# Altium schematic sheet Out_current_sensor_UVW.SchDoc
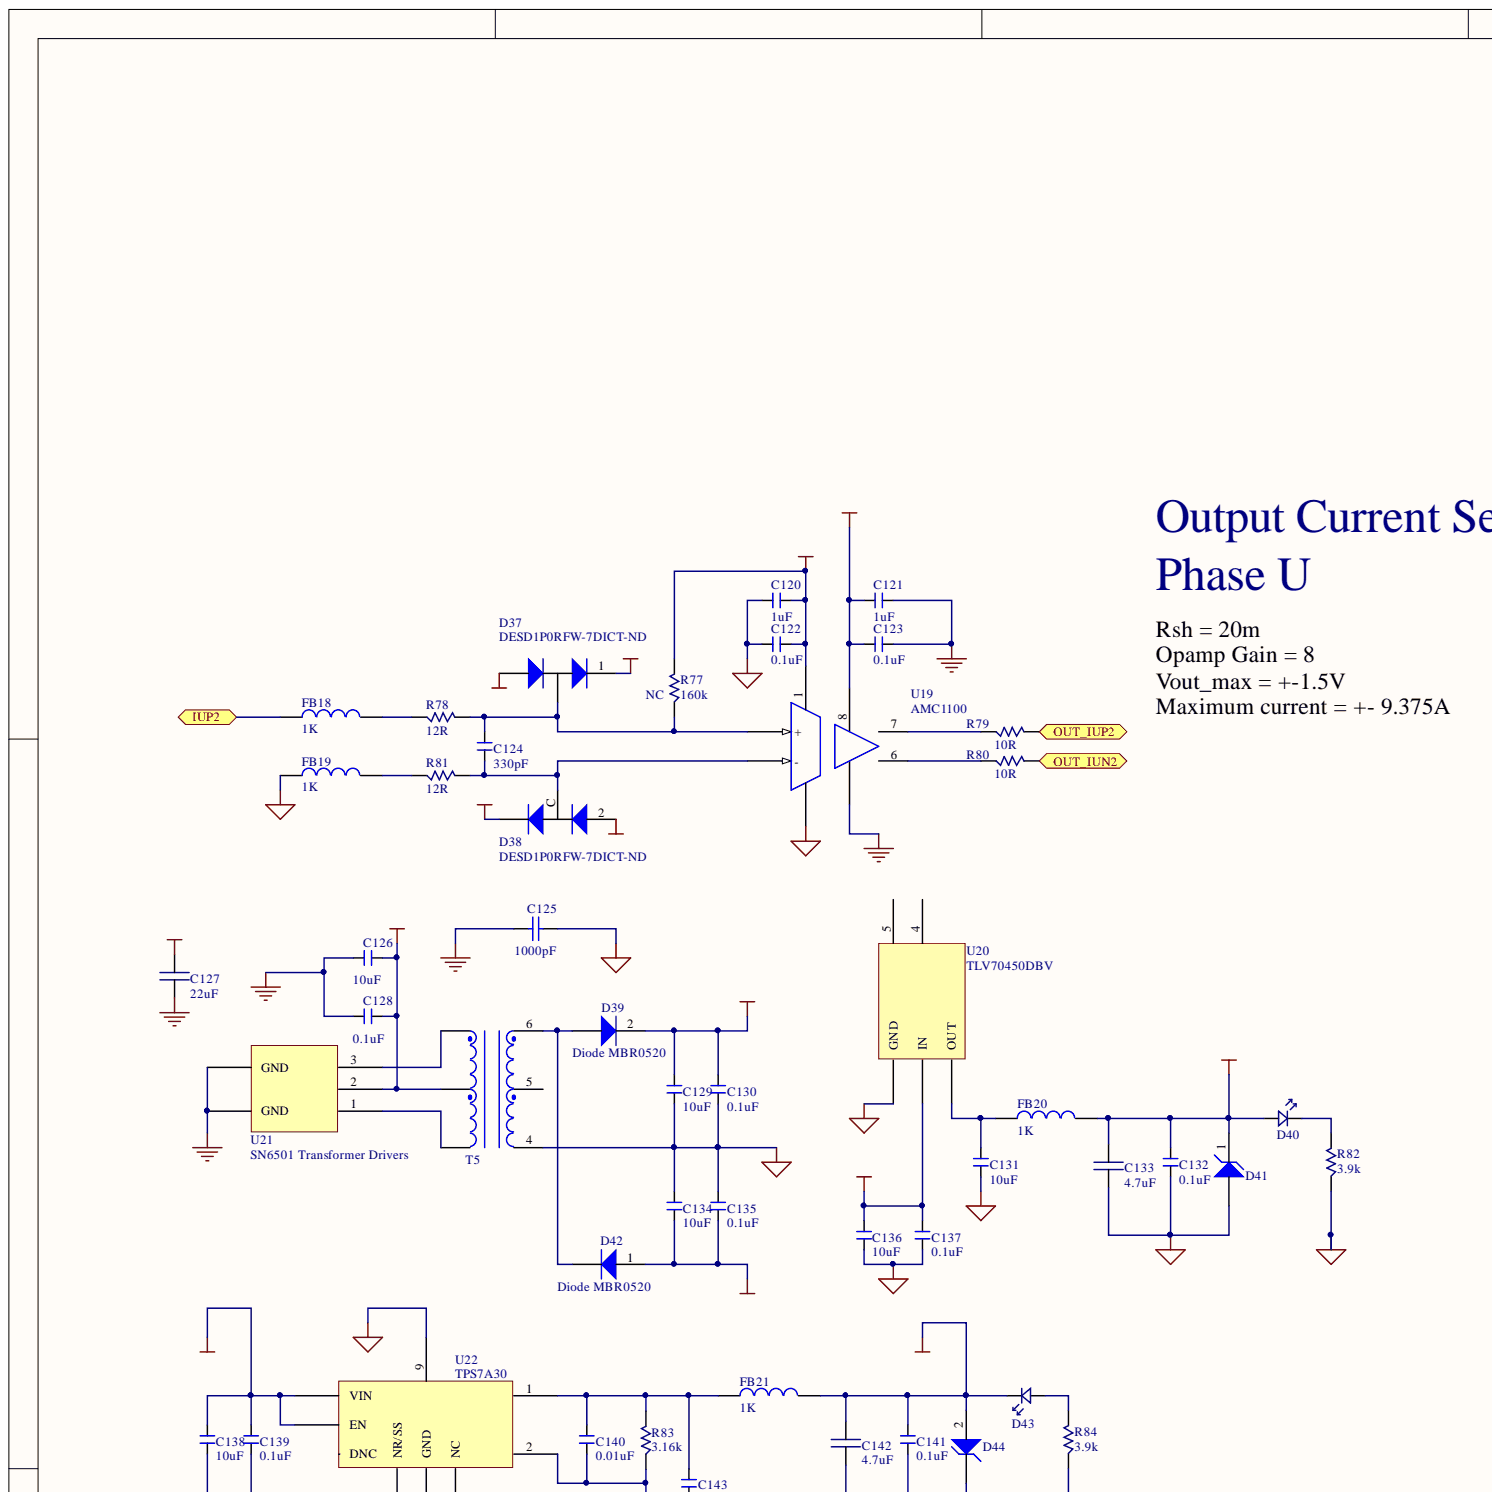
[diagram p0: Out_current_sensor_UVW.SchDoc, top left]
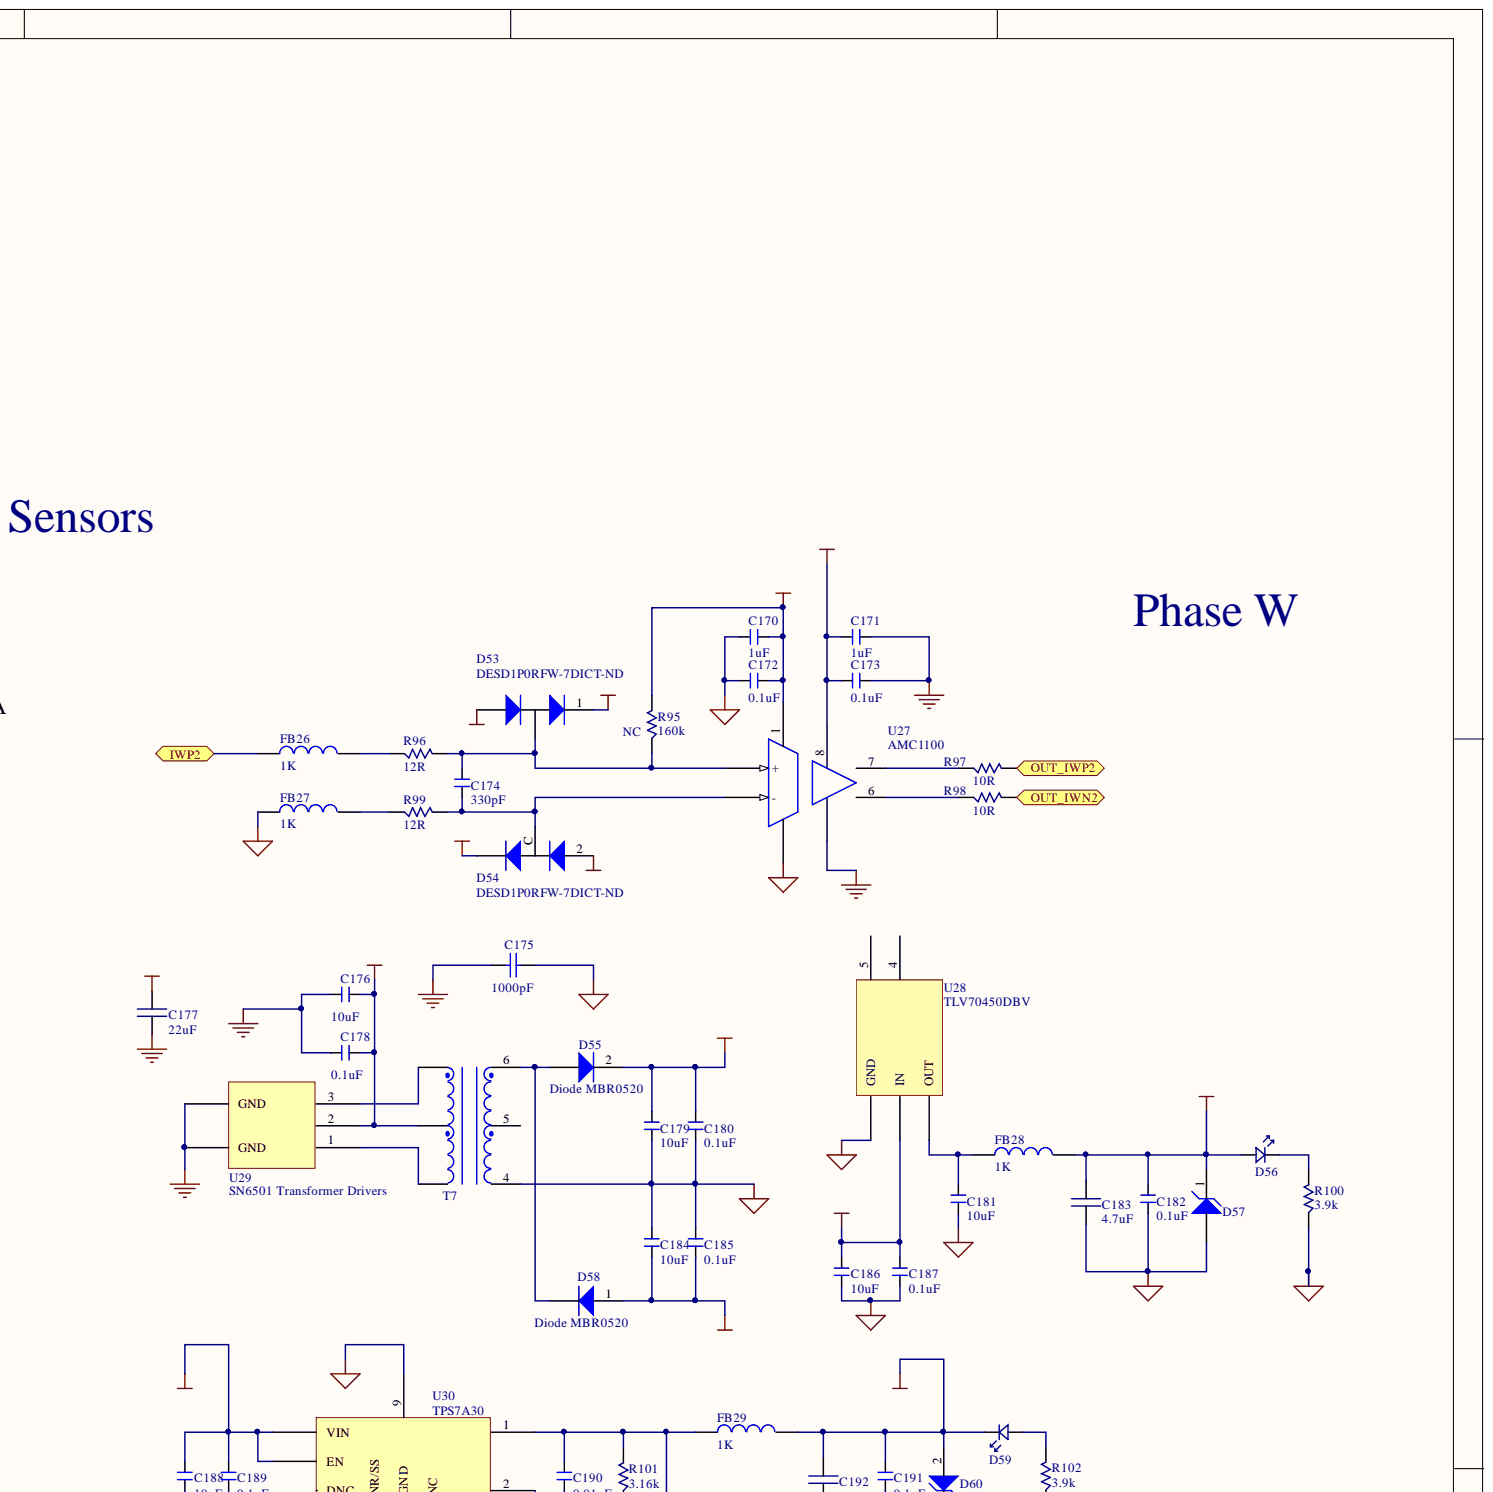
[diagram p1: Out_current_sensor_UVW.SchDoc, top right]
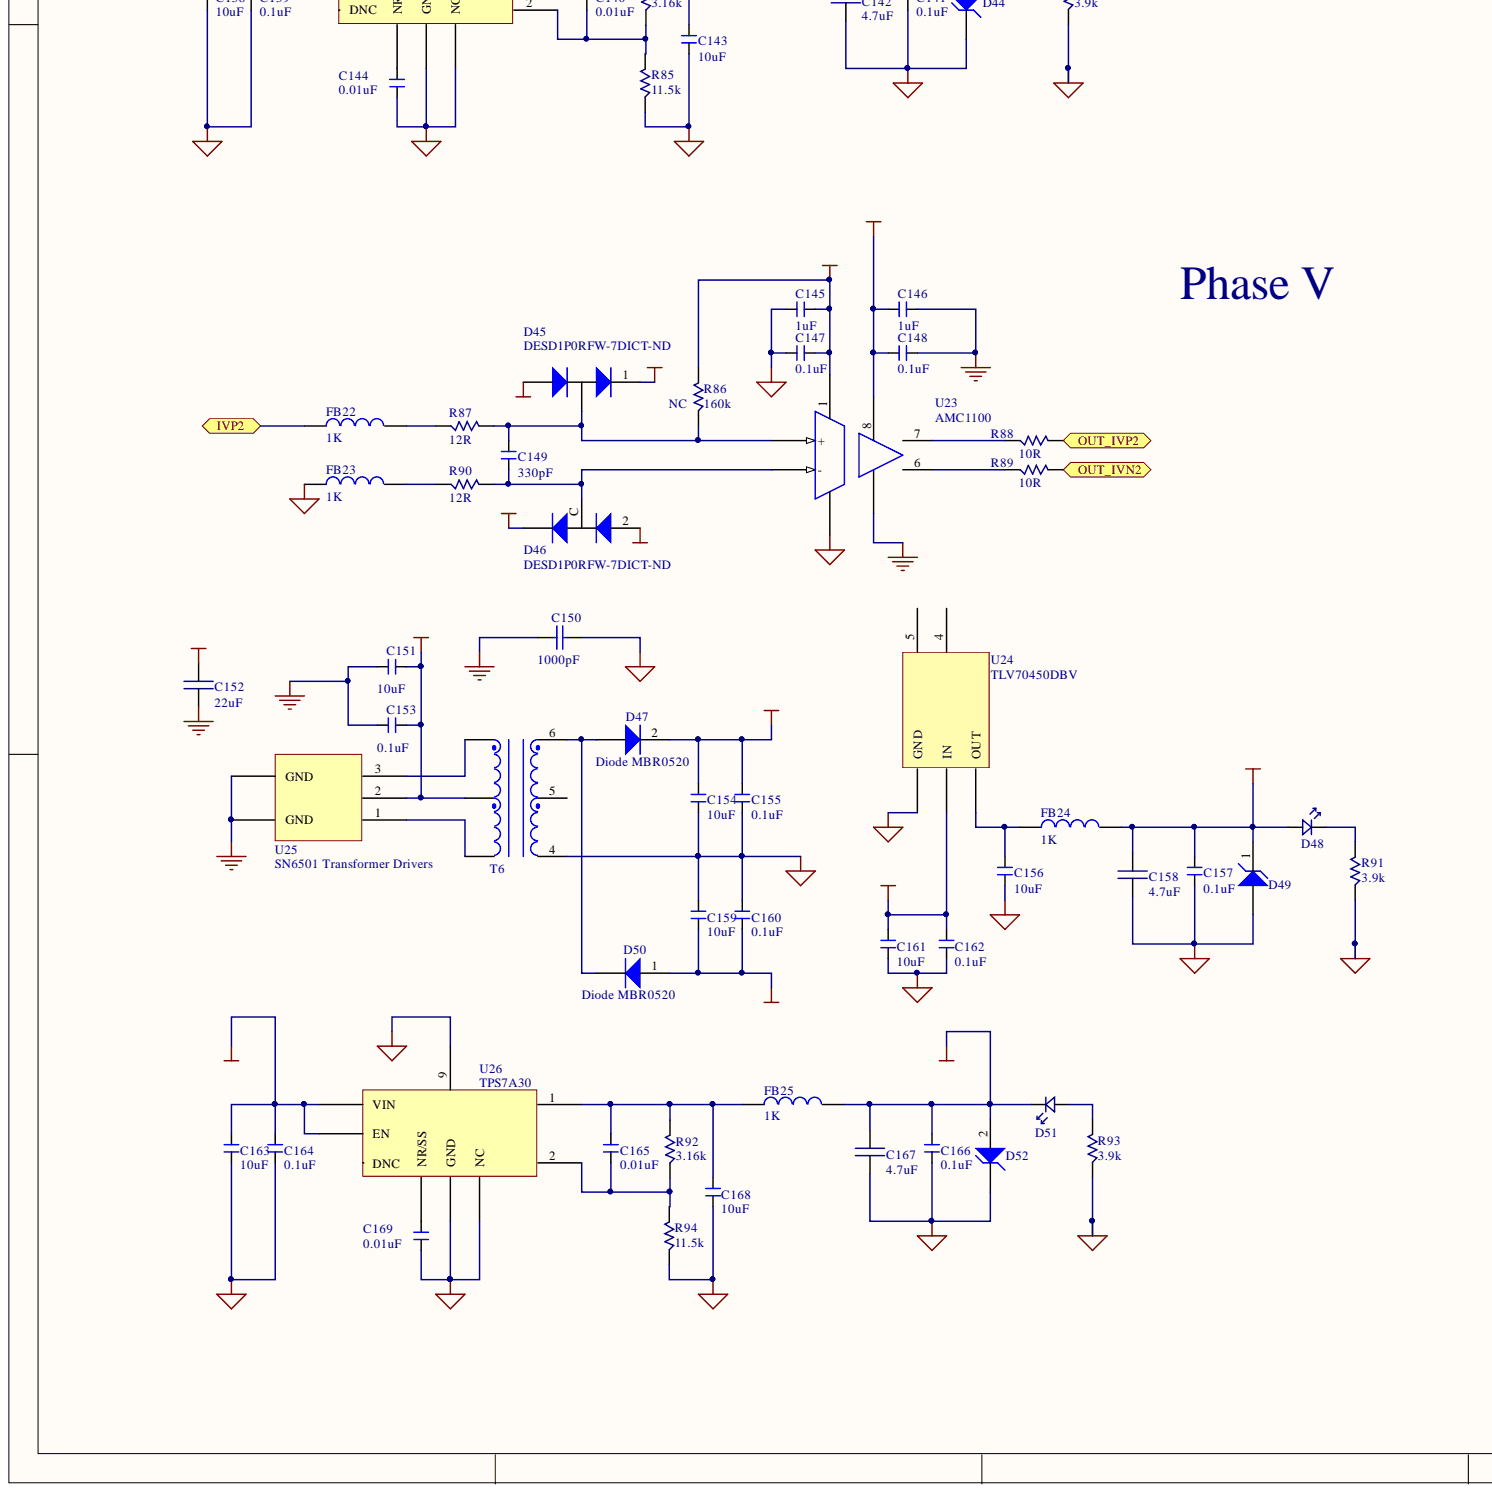
[diagram p2: Out_current_sensor_UVW.SchDoc, bottom left]
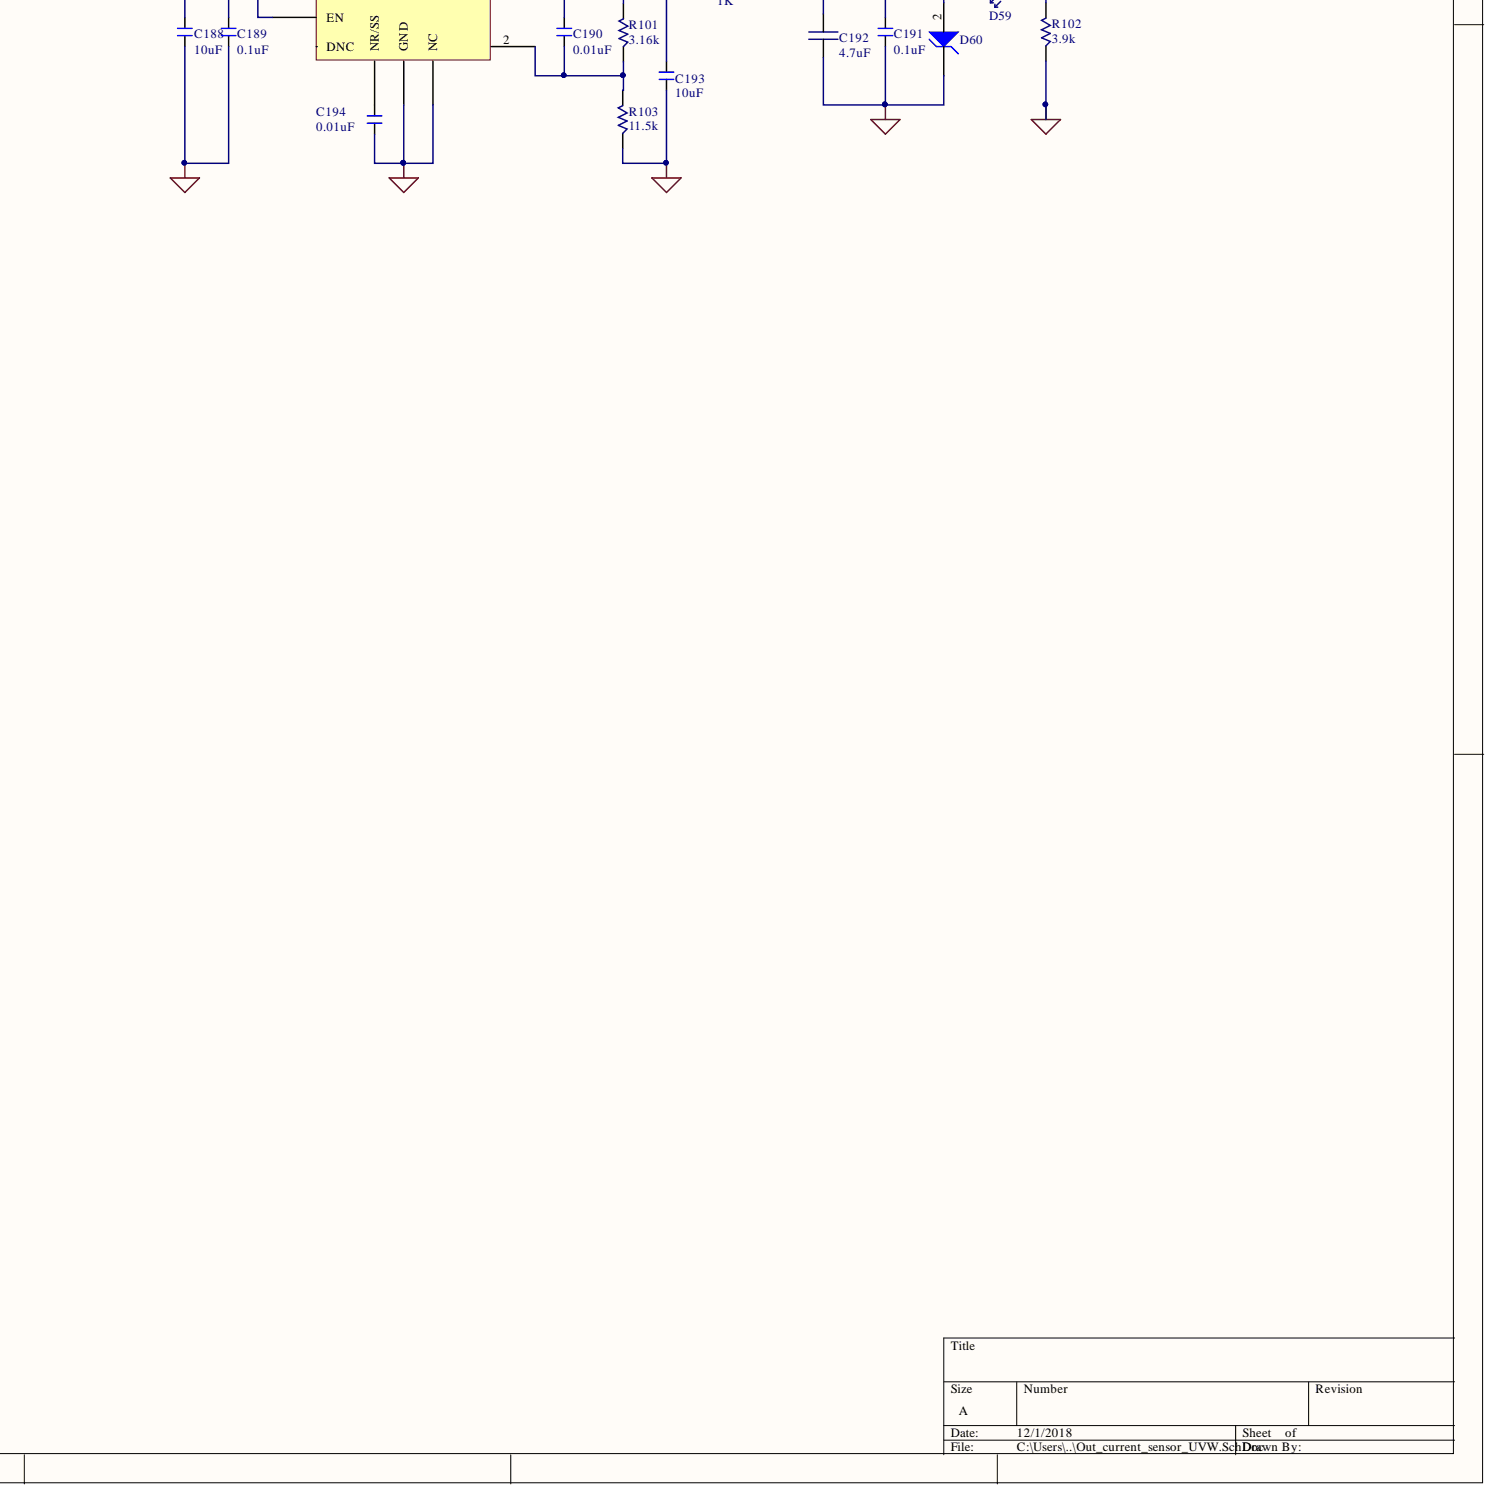
[diagram p3: Out_current_sensor_UVW.SchDoc, bottom right]

## Parts
C120  value 1uF  [0603 (1608 Metric)]  (hidden comment: Cap 0603_new)
C121  value 1uF  [0603 (1608 Metric)]  (hidden comment: Cap 0603_new)
C122  value 0.1uF  [0603 (1608 Metric)]  (hidden comment: Cap 0603_new)
C123  value 0.1uF  [0603 (1608 Metric)]  (hidden comment: Cap 0603_new)
C124  value 330pF  [0603 (1608 Metric)]  (hidden comment: Cap 0603_new)
C125  value 1000pF  [RAD-0.3]  (hidden comment: Cap TH)
C126  value 10uF  [0603 (1608 Metric)]  (hidden comment: Cap 0603_new)
C127  value 22uF  [0805 (2012 metric)]  (hidden comment: Cap 0805)
C128  value 0.1uF  [0603 (1608 Metric)]  (hidden comment: Cap 0603_new)
C129  value 10uF  [0603 (1608 Metric)]  (hidden comment: Cap 0603_new)
C130  value 0.1uF  [0603 (1608 Metric)]  (hidden comment: Cap 0603_new)
C131  value 10uF  [0603 (1608 Metric)]  (hidden comment: Cap 0603_new)
C132  value 0.1uF  [0603 (1608 Metric)]  (hidden comment: Cap 0603_new)
C133  value 4.7uF  [0805 (2012 metric)]  (hidden comment: Cap 0805)
C134  value 10uF  [0603 (1608 Metric)]  (hidden comment: Cap 0603_new)
C135  value 0.1uF  [0603 (1608 Metric)]  (hidden comment: Cap 0603_new)
C136  value 10uF  [0603 (1608 Metric)]  (hidden comment: Cap 0603_new)
C137  value 0.1uF  [0603 (1608 Metric)]  (hidden comment: Cap 0603_new)
C138  value 10uF  [0603 (1608 Metric)]  (hidden comment: Cap 0603_new)
C139  value 0.1uF  [0603 (1608 Metric)]  (hidden comment: Cap 0603_new)
C140  value 0.01uF  [0603 (1608 Metric)]  (hidden comment: Cap 0603_new)
C141  value 0.1uF  [0603 (1608 Metric)]  (hidden comment: Cap 0603_new)
C142  value 4.7uF  [0805 (2012 metric)]  (hidden comment: Cap 0805)
C143  value 10uF  [0603 (1608 Metric)]  (hidden comment: Cap 0603_new)
C144  value 0.01uF  [0603 (1608 Metric)]  (hidden comment: Cap 0603_new)
C145  value 1uF  [0603 (1608 Metric)]  (hidden comment: Cap 0603_new)
C146  value 1uF  [0603 (1608 Metric)]  (hidden comment: Cap 0603_new)
C147  value 0.1uF  [0603 (1608 Metric)]  (hidden comment: Cap 0603_new)
C148  value 0.1uF  [0603 (1608 Metric)]  (hidden comment: Cap 0603_new)
C149  value 330pF  [0603 (1608 Metric)]  (hidden comment: Cap 0603_new)
C150  value 1000pF  [RAD-0.3]  (hidden comment: Cap TH)
C151  value 10uF  [0603 (1608 Metric)]  (hidden comment: Cap 0603_new)
C152  value 22uF  [0805 (2012 metric)]  (hidden comment: Cap 0805)
C153  value 0.1uF  [0603 (1608 Metric)]  (hidden comment: Cap 0603_new)
C154  value 10uF  [0603 (1608 Metric)]  (hidden comment: Cap 0603_new)
C155  value 0.1uF  [0603 (1608 Metric)]  (hidden comment: Cap 0603_new)
C156  value 10uF  [0603 (1608 Metric)]  (hidden comment: Cap 0603_new)
C157  value 0.1uF  [0603 (1608 Metric)]  (hidden comment: Cap 0603_new)
C158  value 4.7uF  [0805 (2012 metric)]  (hidden comment: Cap 0805)
C159  value 10uF  [0603 (1608 Metric)]  (hidden comment: Cap 0603_new)
C160  value 0.1uF  [0603 (1608 Metric)]  (hidden comment: Cap 0603_new)
C161  value 10uF  [0603 (1608 Metric)]  (hidden comment: Cap 0603_new)
C162  value 0.1uF  [0603 (1608 Metric)]  (hidden comment: Cap 0603_new)
C163  value 10uF  [0603 (1608 Metric)]  (hidden comment: Cap 0603_new)
C164  value 0.1uF  [0603 (1608 Metric)]  (hidden comment: Cap 0603_new)
C165  value 0.01uF  [0603 (1608 Metric)]  (hidden comment: Cap 0603_new)
C166  value 0.1uF  [0603 (1608 Metric)]  (hidden comment: Cap 0603_new)
C167  value 4.7uF  [0805 (2012 metric)]  (hidden comment: Cap 0805)
C168  value 10uF  [0603 (1608 Metric)]  (hidden comment: Cap 0603_new)
C169  value 0.01uF  [0603 (1608 Metric)]  (hidden comment: Cap 0603_new)
C170  value 1uF  [0603 (1608 Metric)]  (hidden comment: Cap 0603_new)
C171  value 1uF  [0603 (1608 Metric)]  (hidden comment: Cap 0603_new)
C172  value 0.1uF  [0603 (1608 Metric)]  (hidden comment: Cap 0603_new)
C173  value 0.1uF  [0603 (1608 Metric)]  (hidden comment: Cap 0603_new)
C174  value 330pF  [0603 (1608 Metric)]  (hidden comment: Cap 0603_new)
C175  value 1000pF  [RAD-0.3]  (hidden comment: Cap TH)
C176  value 10uF  [0603 (1608 Metric)]  (hidden comment: Cap 0603_new)
C177  value 22uF  [0805 (2012 metric)]  (hidden comment: Cap 0805)
C178  value 0.1uF  [0603 (1608 Metric)]  (hidden comment: Cap 0603_new)
C179  value 10uF  [0603 (1608 Metric)]  (hidden comment: Cap 0603_new)
C180  value 0.1uF  [0603 (1608 Metric)]  (hidden comment: Cap 0603_new)
C181  value 10uF  [0603 (1608 Metric)]  (hidden comment: Cap 0603_new)
C182  value 0.1uF  [0603 (1608 Metric)]  (hidden comment: Cap 0603_new)
C183  value 4.7uF  [0805 (2012 metric)]  (hidden comment: Cap 0805)
C184  value 10uF  [0603 (1608 Metric)]  (hidden comment: Cap 0603_new)
C185  value 0.1uF  [0603 (1608 Metric)]  (hidden comment: Cap 0603_new)
C186  value 10uF  [0603 (1608 Metric)]  (hidden comment: Cap 0603_new)
C187  value 0.1uF  [0603 (1608 Metric)]  (hidden comment: Cap 0603_new)
C188  value 10uF  [0603 (1608 Metric)]  (hidden comment: Cap 0603_new)
C189  value 0.1uF  [0603 (1608 Metric)]  (hidden comment: Cap 0603_new)
C190  value 0.01uF  [0603 (1608 Metric)]  (hidden comment: Cap 0603_new)
C191  value 0.1uF  [0603 (1608 Metric)]  (hidden comment: Cap 0603_new)
C192  value 4.7uF  [0805 (2012 metric)]  (hidden comment: Cap 0805)
C193  value 10uF  [0603 (1608 Metric)]  (hidden comment: Cap 0603_new)
C194  value 0.01uF  [0603 (1608 Metric)]  (hidden comment: Cap 0603_new)
D37  Part: DESD1P0RFW-7DICT-ND  [SOT323_ESD]  (hidden comment: TVS - Diodes)
D38  Part: DESD1P0RFW-7DICT-ND  [SOT323_ESD]  (hidden comment: TVS - Diodes)
D39  Diode MBR0520  [SOD-123]
D40  LED  [0805 (2012 Metric) Diode]  (value not drawn)
D41  (no value)  [POWERDI]
D42  Diode MBR0520  [SOD-123]
D43  LED  [0805 (2012 Metric) Diode]  (value not drawn)
D44  (no value)  [POWERDI]
D45  Part: DESD1P0RFW-7DICT-ND  [SOT323_ESD]  (hidden comment: TVS - Diodes)
D46  Part: DESD1P0RFW-7DICT-ND  [SOT323_ESD]  (hidden comment: TVS - Diodes)
D47  Diode MBR0520  [SOD-123]
D48  LED  [0805 (2012 Metric) Diode]  (value not drawn)
D49  (no value)  [POWERDI]
D50  Diode MBR0520  [SOD-123]
D51  LED  [0805 (2012 Metric) Diode]  (value not drawn)
D52  (no value)  [POWERDI]
D53  Part: DESD1P0RFW-7DICT-ND  [SOT323_ESD]  (hidden comment: TVS - Diodes)
D54  Part: DESD1P0RFW-7DICT-ND  [SOT323_ESD]  (hidden comment: TVS - Diodes)
D55  Diode MBR0520  [SOD-123]
D56  LED  [0805 (2012 Metric) Diode]  (value not drawn)
D57  (no value)  [POWERDI]
D58  Diode MBR0520  [SOD-123]
D59  LED  [0805 (2012 Metric) Diode]  (value not drawn)
D60  (no value)  [POWERDI]
FB18  value 1K  [0603 (1608 Metric)]  (hidden comment: Ferrite bead)
FB19  value 1K  [0603 (1608 Metric)]  (hidden comment: Ferrite bead)
FB20  value 1K  [0603 (1608 Metric)]  (hidden comment: Ferrite bead)
FB21  value 1K  [0603 (1608 Metric)]  (hidden comment: Ferrite bead)
FB22  value 1K  [0603 (1608 Metric)]  (hidden comment: Ferrite bead)
FB23  value 1K  [0603 (1608 Metric)]  (hidden comment: Ferrite bead)
FB24  value 1K  [0603 (1608 Metric)]  (hidden comment: Ferrite bead)
FB25  value 1K  [0603 (1608 Metric)]  (hidden comment: Ferrite bead)
FB26  value 1K  [0603 (1608 Metric)]  (hidden comment: Ferrite bead)
FB27  value 1K  [0603 (1608 Metric)]  (hidden comment: Ferrite bead)
FB28  value 1K  [0603 (1608 Metric)]  (hidden comment: Ferrite bead)
FB29  value 1K  [0603 (1608 Metric)]  (hidden comment: Ferrite bead)
R77  NC  value 160k  [0603 (1608 Metric)]
R78  value 12R  [1206]  (hidden comment: Resistor 1206)
R79  value 10R  [0603 (1608 Metric)]  (hidden comment: Resistor 0603)
R80  value 10R  [0603 (1608 Metric)]  (hidden comment: Resistor 0603)
R81  value 12R  [1206]  (hidden comment: Resistor 1206)
R82  value 3.9k  [0603 (1608 Metric)]  (hidden comment: Resistor 0603)
R83  value 3.16k  [0603 (1608 Metric)]  (hidden comment: Resistor 0603)
R84  value 3.9k  [0603 (1608 Metric)]  (hidden comment: Resistor 0603)
R85  value 11.5k  [0603 (1608 Metric)]  (hidden comment: Resistor 0603)
R86  NC  value 160k  [0603 (1608 Metric)]
R87  value 12R  [1206]  (hidden comment: Resistor 1206)
R88  value 10R  [0603 (1608 Metric)]  (hidden comment: Resistor 0603)
R89  value 10R  [0603 (1608 Metric)]  (hidden comment: Resistor 0603)
R90  value 12R  [1206]  (hidden comment: Resistor 1206)
R91  value 3.9k  [0603 (1608 Metric)]  (hidden comment: Resistor 0603)
R92  value 3.16k  [0603 (1608 Metric)]  (hidden comment: Resistor 0603)
R93  value 3.9k  [0603 (1608 Metric)]  (hidden comment: Resistor 0603)
R94  value 11.5k  [0603 (1608 Metric)]  (hidden comment: Resistor 0603)
R95  NC  value 160k  [0603 (1608 Metric)]
R96  value 12R  [1206]  (hidden comment: Resistor 1206)
R97  value 10R  [0603 (1608 Metric)]  (hidden comment: Resistor 0603)
R98  value 10R  [0603 (1608 Metric)]  (hidden comment: Resistor 0603)
R99  value 12R  [1206]  (hidden comment: Resistor 1206)
R100  value 3.9k  [0603 (1608 Metric)]  (hidden comment: Resistor 0603)
R101  value 3.16k  [0603 (1608 Metric)]  (hidden comment: Resistor 0603)
R102  value 3.9k  [0603 (1608 Metric)]  (hidden comment: Resistor 0603)
R103  value 11.5k  [0603 (1608 Metric)]  (hidden comment: Resistor 0603)
T5  750313769  [Xmr SN6501]  (value not drawn)
T6  750313769  [Xmr SN6501]  (value not drawn)
T7  750313769  [Xmr SN6501]  (value not drawn)
U19  AMC1100  [SOIC8]
U20  TLV70450DBV  [SOT23-5]
U21  SN6501 Transformer Drivers  [SOT23-5]
U22  TPS7A30  [8-TSSOP]
U23  AMC1100  [SOIC8]
U24  TLV70450DBV  [SOT23-5]
U25  SN6501 Transformer Drivers  [SOT23-5]
U26  TPS7A30  [8-TSSOP]
U27  AMC1100  [SOIC8]
U28  TLV70450DBV  [SOT23-5]
U29  SN6501 Transformer Drivers  [SOT23-5]
U30  TPS7A30  [8-TSSOP]

## Ports
IUP2, IVP2, IWP2, OUT_IUN2, OUT_IUP2, OUT_IVN2, OUT_IVP2, OUT_IWN2, OUT_IWP2

## Nets
+5V_LV: C121.1 C123.1 C126.2 C127.2 C128.2 C146.1 C148.1 C151.2 C152.2 C153.2 C171.1 C173.1 C176.2 C177.2 C178.2 T5.2 T6.2 T7.2 U19.8 U21.2 U23.8 U25.2 U27.8 U29.2
+5V_USH2: C120.2 C122.2 C132.2 C133.2 D37.1 D38.1 D40.A D41.1 FB20.2 R77.2 U19.1
+5V_VSH2: C145.2 C147.2 C157.2 C158.2 D45.1 D46.1 D48.A D49.1 FB24.2 R86.2 U23.1
+5V_WSH2: C170.2 C172.2 C182.2 C183.2 D53.1 D54.1 D56.A D57.1 FB28.2 R95.2 U27.1
+6V_USH2: C129.2 C130.2 C136.2 C137.2 D39.2 U20.2(IN)
+6V_VSH2: C154.2 C155.2 C161.2 C162.2 D47.2 U24.2(IN)
+6V_WSH2: C179.2 C180.2 C186.2 C187.2 D55.2 U28.2(IN)
-5V_USH2: C141.2 C142.2 D37.2 D38.2 D43.K D44.2 FB21.2
-5V_VSH2: C166.2 C167.2 D45.2 D46.2 D51.K D52.2 FB25.2
-5V_WSH2: C191.2 C192.2 D53.2 D54.2 D59.K D60.2 FB29.2
-6V_USH2: C134.1 C135.1 C138.2 C139.2 D42.1 U22.5(EN) U22.8(VIN)
-6V_VSH2: C159.1 C160.1 C163.2 C164.2 D50.1 U26.5(EN) U26.8(VIN)
-6V_WSH2: C184.1 C185.1 C188.2 C189.2 D58.1 U30.5(EN) U30.8(VIN)
GND_LV: C121.2 C123.2 C125.1 C126.1 C127.1 C128.1 C146.2 C148.2 C150.1 C151.1 C152.1 C153.1 C171.2 C173.2 C175.1 C176.1 C177.1 C178.1 U19.5 U21.4(GND) U21.5(GND) U23.5 U25.4(GND) U25.5(GND) U27.5 U29.4(GND) U29.5(GND)
GND_USH2: C120.1 C122.1 C125.2 C129.1 C130.1 C131.1 C132.1 C133.1 C134.2 C135.2 C136.1 C137.1 C138.1 C139.1 C141.1 C142.1 C143.1 C144.1 D41.2 D44.1 FB19.1 R82.1 R84.1 R85.1 T5.4 U19.4 U20.1(GND) U22.3(NC) U22.4(GND) U22.9
GND_VSH2: C145.1 C147.1 C150.2 C154.1 C155.1 C156.1 C157.1 C158.1 C159.2 C160.2 C161.1 C162.1 C163.1 C164.1 C166.1 C167.1 C168.1 C169.1 D49.2 D52.1 FB23.1 R91.1 R93.1 R94.1 T6.4 U23.4 U24.1(GND) U26.3(NC) U26.4(GND) U26.9
GND_WSH2: C170.1 C172.1 C175.2 C179.1 C180.1 C181.1 C182.1 C183.1 C184.2 C185.2 C186.1 C187.1 C188.1 C189.1 C191.1 C192.1 C193.1 C194.1 D57.2 D60.1 FB27.1 R100.1 R102.1 R103.1 T7.4 U27.4 U28.1(GND) U30.3(NC) U30.4(GND) U30.9
IUP2: FB18.1 ; port IUP2
IVP2: FB22.1 ; port IVP2
IWP2: FB26.1 ; port IWP2
OUT_IUN2: R80.2 ; port OUT_IUN2
OUT_IUP2: R79.2 ; port OUT_IUP2
OUT_IVN2: R89.2 ; port OUT_IVN2
OUT_IVP2: R88.2 ; port OUT_IVP2
OUT_IWN2: R98.2 ; port OUT_IWN2
OUT_IWP2: R97.2 ; port OUT_IWP2
NetC124_1: C124.1 D37.C R77.1 R78.2 U19.2(+)
NetC124_2: C124.2 D38.C R81.2 U19.3(-)
NetC131_2: C131.2 FB20.1 U20.3(OUT)
NetC140_1: C140.1 C143.2 FB21.1 R83.2 U22.1
NetC140_2: C140.2 R83.1 R85.2 U22.2
NetC144_2: C144.2 U22.6(NR/SS)
NetC149_1: C149.1 D45.C R86.1 R87.2 U23.2(+)
NetC149_2: C149.2 D46.C R90.2 U23.3(-)
NetC156_2: C156.2 FB24.1 U24.3(OUT)
NetC165_1: C165.1 C168.2 FB25.1 R92.2 U26.1
NetC165_2: C165.2 R92.1 R94.2 U26.2
NetC169_2: C169.2 U26.6(NR/SS)
NetC174_1: C174.1 D53.C R95.1 R96.2 U27.2(+)
NetC174_2: C174.2 D54.C R99.2 U27.3(-)
NetC181_2: C181.2 FB28.1 U28.3(OUT)
NetC190_1: C190.1 C193.2 FB29.1 R101.2 U30.1
NetC190_2: C190.2 R101.1 R103.2 U30.2
NetC194_2: C194.2 U30.6(NR/SS)
NetD39_1: D39.1 D42.2 T5.6
NetD40_K: D40.K R82.2
NetD43_A: D43.A R84.2
NetD47_1: D47.1 D50.2 T6.6
NetD48_K: D48.K R91.2
NetD51_A: D51.A R93.2
NetD55_1: D55.1 D58.2 T7.6
NetD56_K: D56.K R100.2
NetD59_A: D59.A R102.2
NetFB18_2: FB18.2 R78.1
NetFB19_2: FB19.2 R81.1
NetFB22_2: FB22.2 R87.1
NetFB23_2: FB23.2 R90.1
NetFB26_2: FB26.2 R96.1
NetFB27_2: FB27.2 R99.1
NetR79_1: R79.1 U19.7
NetR80_1: R80.1 U19.6
NetR88_1: R88.1 U23.7
NetR89_1: R89.1 U23.6
NetR97_1: R97.1 U27.7
NetR98_1: R98.1 U27.6
NetT5_1: T5.1 U21.3
NetT5_3: T5.3 U21.1
NetT6_1: T6.1 U25.3
NetT6_3: T6.3 U25.1
NetT7_1: T7.1 U29.3
NetT7_3: T7.3 U29.1

Not connected: T5 5; T6 5; T7 5; U20 4 5; U22 7; U24 4 5; U26 7; U28 4 5; U30 7

## Library data of the parts
C120 C121 C145 C146 C170 C171 = Cap 0603_new: CAP CER 1UF 50V X5R 0603; part: 1276-1860-1-ND; Component Kind: Standard; Library Name: Library_Microgrid.SchLib
C122 C123 C128 C130 C132 C135 C137 C139 C141 C147 C148 C153 C155 C157 C160 C162 C164 C166 C172 C173 C178 C180 C182 C185 C187 C189 C191 = Cap 0603_new: CAP CER 0.1UF 50V Y5V 0603; part: 311-1343-6-ND; Component Kind: Standard; Library Name: Library_Microgrid.SchLib
  C128 C178: Value: 0.1uF
C124 C149 C174 = Cap 0603_new: CAP CER 330PF 50V C0G 0603; part: 445-7033-2-ND; Component Kind: Standard; Library Name: Library_Microgrid.SchLib
C125 C150 C175 = Cap: CAP CER 1000PF 1KV Y5P RADIAL; Part: 1251PH-ND; Component Kind: Standard; LatestRevisionDate: 17-Jul-2002; LatestRevisionNote: Re-released for DXP Platform.; Library Name: Miscellaneous Devices.IntLib; PackageDescription: Radial Cap, Thru-Hole; 2 Leads; 0.3 in Pin Spacing; PackageReference: RAD-0.3; Published: 8-Jun-2000; Signal Integrity: Cap; Simulation: CAP; Publisher: Altium Limited
C126 C129 C131 C134 C136 C138 C143 C151 C154 C156 C159 C161 C163 C168 C176 C179 C181 C184 C186 C188 C193 = Cap 0603_new: CAP CER 10UF 35V X5R 0805; part: 490-10515-1-ND; Component Kind: Standard; Library Name: Library_Microgrid.SchLib
C127 C152 C177 = Cap 0805: CAP CER 22UF 35V X5R 0805; Part: 445-14428-1-ND; Component Kind: Standard; Library Name: Library_Microgrid.SchLib
C133 C142 C158 C167 C183 C192 = Cap 0805: CAP CER 4.7UF 50V X5R 0805; Part: 445-5980-1-ND; Component Kind: Standard; Library Name: Library_Microgrid.SchLib
C140 C144 C165 C169 C190 C194 = Cap 0603_new: CAP CER 10000PF 100V X7R 0603; part: 478-7418-1-ND; Component Kind: Standard; Library Name: Library_Microgrid.SchLib
D37 D38 D45 D46 D53 D54 = Diode_ESD: TVS DIODE 70VWM 8VC SOT323; Value: ESD; Component Kind: Standard; Library Name: Library_Microgrid.SchLib
D39 D42 D47 D50 D55 D58 = Diode MBR0520: DIODE SCHOTTKY 20V 500MA SOD123; Part: MBR0520LT1GOSCT-ND; Component Kind: Standard; Library Name: Library_Microgrid.SchLib
D40 D43 D48 D51 D56 D59 = LED: LED 0805; Component Kind: Standard; Library Name: Library_Microgrid.SchLib
D41 D44 D49 D52 D57 D60 = Diode Zener POWERDI123: Part: MMSZ5228B-FDICT-ND; Component Kind: Standard; Description: DIODE ZENER POWERDI123; Library Name: Library_Microgrid.SchLib
FB18-FB29 = Ferrite bead: FERRITE BEAD 1 KOHM 0603 1LN; Part: 445-8672-1-ND; Component Kind: Standard; Library Name: Library_Microgrid.SchLib
R77 R86 R95 = Resistor 0603: Component Kind: Standard; Description: Resistor 0603; Library Name: Library_Microgrid.SchLib
R78 R81 R87 R90 R96 R99 = Resistor 1206: 12 Ohm ±0.5% 0.25W, 1/4W Chip Resistor 1206; Part: 311-2961-1-ND; Component Kind: Standard; Library Name: Library_Microgrid.SchLib
R79 R80 R88 R89 R97 R98 = Resistor 0603: RES SMD 10 OHM 0.05% 1/10W 0603; Part: RNCF0603AKT10R0CT-ND; Component Kind: Standard; Library Name: Library_Microgrid.SchLib
R82 R84 R91 R93 R100 R102 = Resistor 0603: RES SMD 3.9K OHM 5% 1/10W 0603; Part: 541-3.9KGCT-ND; Component Kind: Standard; Library Name: Library_Microgrid.SchLib
R83 R92 R101 = Resistor 0603: RES SMD 3.16K OHM 1% 1/10W 0603; Part: 311-3.16KHRCT-ND; Component Kind: Standard; Library Name: Library_Microgrid.SchLib
R85 R94 R103 = Resistor 0603: RES SMD 11.5K OHM 1% 1/10W 0603; Part: 541-11.5KHCT-ND; Component Kind: Standard; Library Name: Library_Microgrid.SchLib
T5-T7 = 750313769: TRANSFORMER SMD TR1.7 SN6501; Part: 1297-1107-1-ND; Component Kind: Standard; Library Name: Library_Microgrid.SchLib
U19 U23 U27 = AMC1100: IC OPAMP ISOLATION 100KHZ 8SOIC; Part: 296-38822-5-ND; Component Kind: Standard; Library Name: Library_Microgrid.SchLib
U20 U24 U28 = TLV70450DBV: IC REG LDO 5V 0.15A SOT23-5; Part: 296-39287-1-ND; Component Kind: Standard; Library Name: Library_Microgrid.SchLib
U21 U25 U29 = SN6501: IC REG CONV PWR SUPPLIES SOT23-5; Part: 296-37700-1-ND; Component Kind: Standard; Library Name: Library_Microgrid.SchLib
U22 U26 U30 = TPS7A30: IC REG LDO NEG ADJ 0.2A 8MSOP; Part: 296-27750-1-ND; Component Kind: Standard; Library Name: Library_Microgrid.SchLib
